# Altium schematic sheet Tartarus Joystick Mod M0 IO.SchDoc("Tartarus Joystick Mod M0 IO")
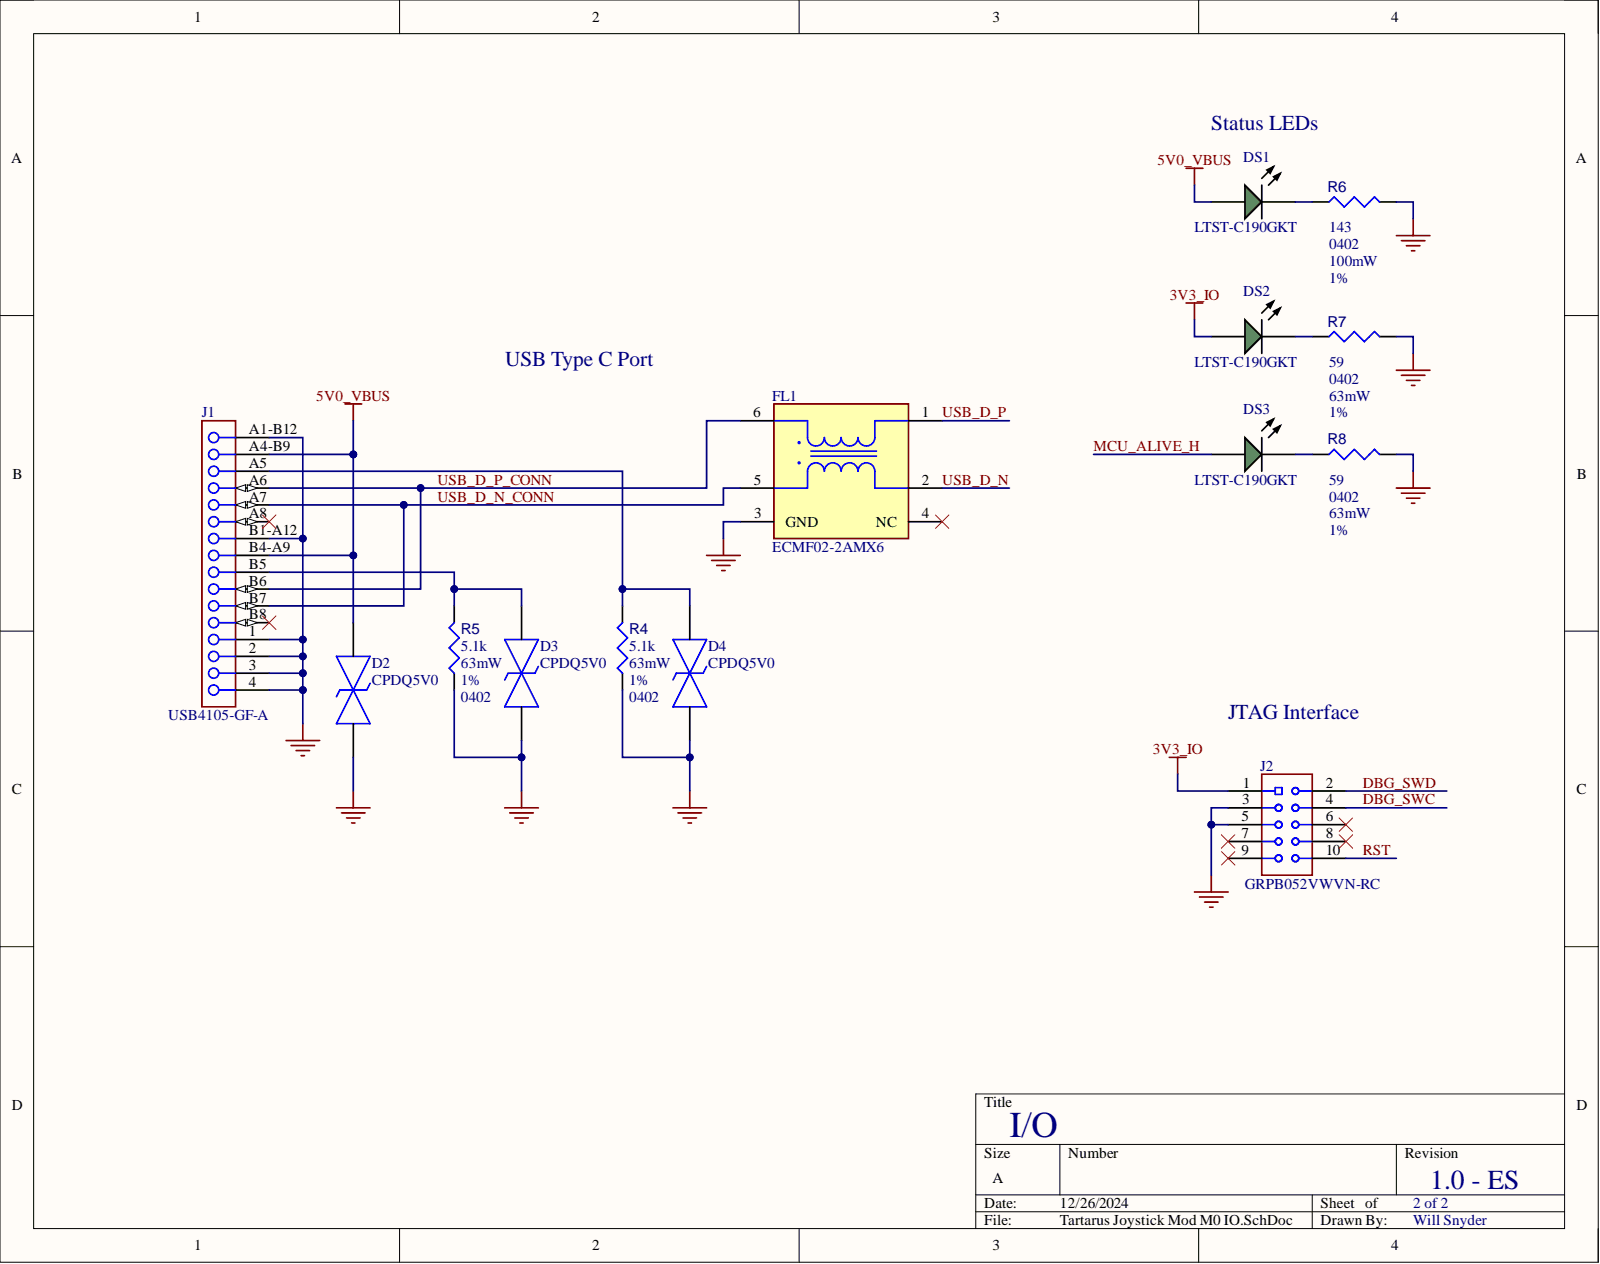
[diagram p0: Tartarus Joystick Mod M0 IO.SchDoc]

## Parts
D2  CPDQ5V0
D3  CPDQ5V0
D4  CPDQ5V0
DS1  LTST-C190GKT
DS2  LTST-C190GKT
DS3  LTST-C190GKT
FL1  ECMF02-2AMX6
J1  USB4105-GF-A
J2  GRPB052VWVN-RC
R4  value 5.1k  Case/Package: 0402  Power: 63mW  Tolerance: 1%  (hidden comment: MCS04020C5101FE000)
R5  value 5.1k  Case/Package: 0402  Power: 63mW  Tolerance: 1%  (hidden comment: MCS04020C5101FE000)
R6  value 143  (hidden comment: ERJ-2RKF1430X)
R7  value 59  (hidden comment: CRCW040259R0FKED)
R8  value 59  (hidden comment: CRCW040259R0FKED)

## Nets
3V3_IO: DS2.1 J2.1
5V0_VBUS: D2.1 DS1.1 J1.A4-B9 J1.B4-A9
GND: D2.2 D3.2 D4.2 FL1.3(GND) J1.1 J1.2 J1.3 J1.4 J1.A1-B12 J1.B1-A12 J2.3 J2.5 R4.2 R5.2 R6.2 R7.2 R8.2
DBG_SWC: J2.4
DBG_SWD: J2.2
MCU_ALIVE_H: DS3.1
RST: J2.10
USB_D_N: FL1.2
USB_D_N_CONN: FL1.5 J1.A7 J1.B7
USB_D_P: FL1.1
USB_D_P_CONN: FL1.6 J1.A6 J1.B6
NetD3_1: D3.1 J1.B5 R5.1
NetD4_1: D4.1 J1.A5 R4.1
NetDS1_2: DS1.2 R6.1
NetDS2_2: DS2.2 R7.1
NetDS3_2: DS3.2 R8.1

Not connected: FL1 4(NC); J1 A8 B8; J2 6 7 8 9

## Library data of the parts
D2-D4 = CMP-004-00042-2: 18.6V Clamp 9.4A (8/20Âµs) Ipp Tvs Diode Surface Mount 0402/SOD-923F; MF: Comchip Technology; MP: CPDQ5V0-HF; Availability: Good; Case/Package: 0402 Comchip; Check_prices: https://www.snapeda.com/parts/CPDQ5V0-HF/Comchip%20Technology/view-part/809934/?ref=eda; Component Kind: Standard; Component Type: Standard; Design Item ID: CPDQ5V0-HF; Library Name: Personal Workspace; Pins: 2; Price: None; Purchase-URL: https://pricing.snapeda.com/search/part/CPDQ5V0-HF/?ref=eda; SnapEDA_Link: https://www.snapeda.com/parts/CPDQ5V0-HF/Comchip%20Technology/view-part/809934/?ref=snap; Source: CPDQ5V0-HF.SchLib
DS1-DS3 = CMP-007-00022-2: LED Uni-Color Green, 100 mW, 30 mA, -55 to 85 degC, 2-Pin SMD, RoHS, Tape and Reel; Manufacturer: Lite-On; Forward Current: 20mA; Forward Voltage: 2.1V; Lens Style: Clear; Luminous Intensity: 6mcd; Power: 100mW; Viewing Angle: 130degrees; Case/Package: 0603; Colour: Green; Component Kind: Standard; Datasheet URL: http://datasheet.octopart.com/LTST-C190GKT-Lite-On-datasheet-158530.pdf; Datasheet Version: Dec-06; Illumination Colour: Green; Library Name: Personal Workspace; Manufacturer URL: http://www.us.liteon.com/; Max Operating Temperature: 85°C; Min Operating Temperature: -55°C; Mounting Technology: SM; Nominal Vf: 2.1V; Package Description: 2-Pin Surface Mount Device, Body 1.6 x 0.8 mm; Package Quantity: 3000; Package Reference: C190GKT; Packaging: TapeandReel; Pins: 2; RoHS Compliant: True
FL1 = CMP-00030-00005-1: Data Line Filter, 2 Function(s), 6 Pin(s), Plastic; Component Kind: Standard; Library Name: Personal Workspace; Max Operating Temperature: 85°C; Min Operating Temperature: -40°C; Mounting Technology: Surface Mount; Pins: 6; RoHS Compliant: Yes
J1 = CMP-002-00095-3: Conn USB 2.0 Type C RCP 24 POS 0.3mm/0.5mm Solder RA SMD 24 Terminal 1 Port T/R; Component Kind: Standard; Library Name: Personal Workspace
J2 = CMP-002-00094-2: Connector Header Through Hole 10 position 0.050 (1.27mm); MF: Sullins; Availability: In Stock; Case/Package: None; Check_prices: https://www.snapeda.com/parts/GRPB052VWVN-RC/Sullins+Connector+Solutions/view-part/?ref=eda; Component Kind: Standard; Component Type: Standard; Design Item ID: GRPB052VWVN-RC; Library Name: Personal Workspace; MP: GRPB052VWVN-RC; Pins: 10; Price: None; Purchase-URL: https://pricing.snapeda.com/search/part/GRPB052VWVN-RC/?ref=eda; SnapEDA_Link: https://www.snapeda.com/parts/GRPB052VWVN-RC/Sullins+Connector+Solutions/view-part/?ref=snap; Source: GRPB052VWVN-RC.SchLib
R4 R5 = CMP-009-00205-1: Fixed Resistor, Thin Film, 0.063W, 5100ohm, 50V, 1% +/-Tol, 50ppm/Cel, Surface Mount, 0402; Component Kind: Standard; Library Name: Personal Workspace; Max Operating Temperature: 125°C; Min Operating Temperature: -55°C; Mounting Technology: Surface Mount; Pins: 2; RoHS Compliant: Yes
R6 = CMP-009-00208-1: Fixed Resistor, Metal Glaze/thick Film, 0.1W, 143ohm, 50V, 1% +/-Tol, 100ppm/Cel, Surface Mount, 0402; Power: 100mW; Case/Package: 0402; Component Kind: Standard; Library Name: Personal Workspace; Max Operating Temperature: 155°C; Min Operating Temperature: -55°C; Mounting Technology: Surface Mount; Pins: 2; RoHS Compliant: Yes; Tolerance: 1%
R7 R8 = CMP-009-00207-1: Fixed Resistor, Metal Glaze/thick Film, 0.063W, 59ohm, 50V, 1% +/-Tol, 100ppm/Cel, Surface Mount, 0402; Power: 63mW; Case/Package: 0402; Component Kind: Standard; Library Name: Personal Workspace; Max Operating Temperature: 155°C; Min Operating Temperature: -55°C; Mounting Technology: Surface Mount; Pins: 2; RoHS Compliant: Yes; Tolerance: 1%
